# Altium schematic sheet PDM Mk21 - Mini.SchDoc
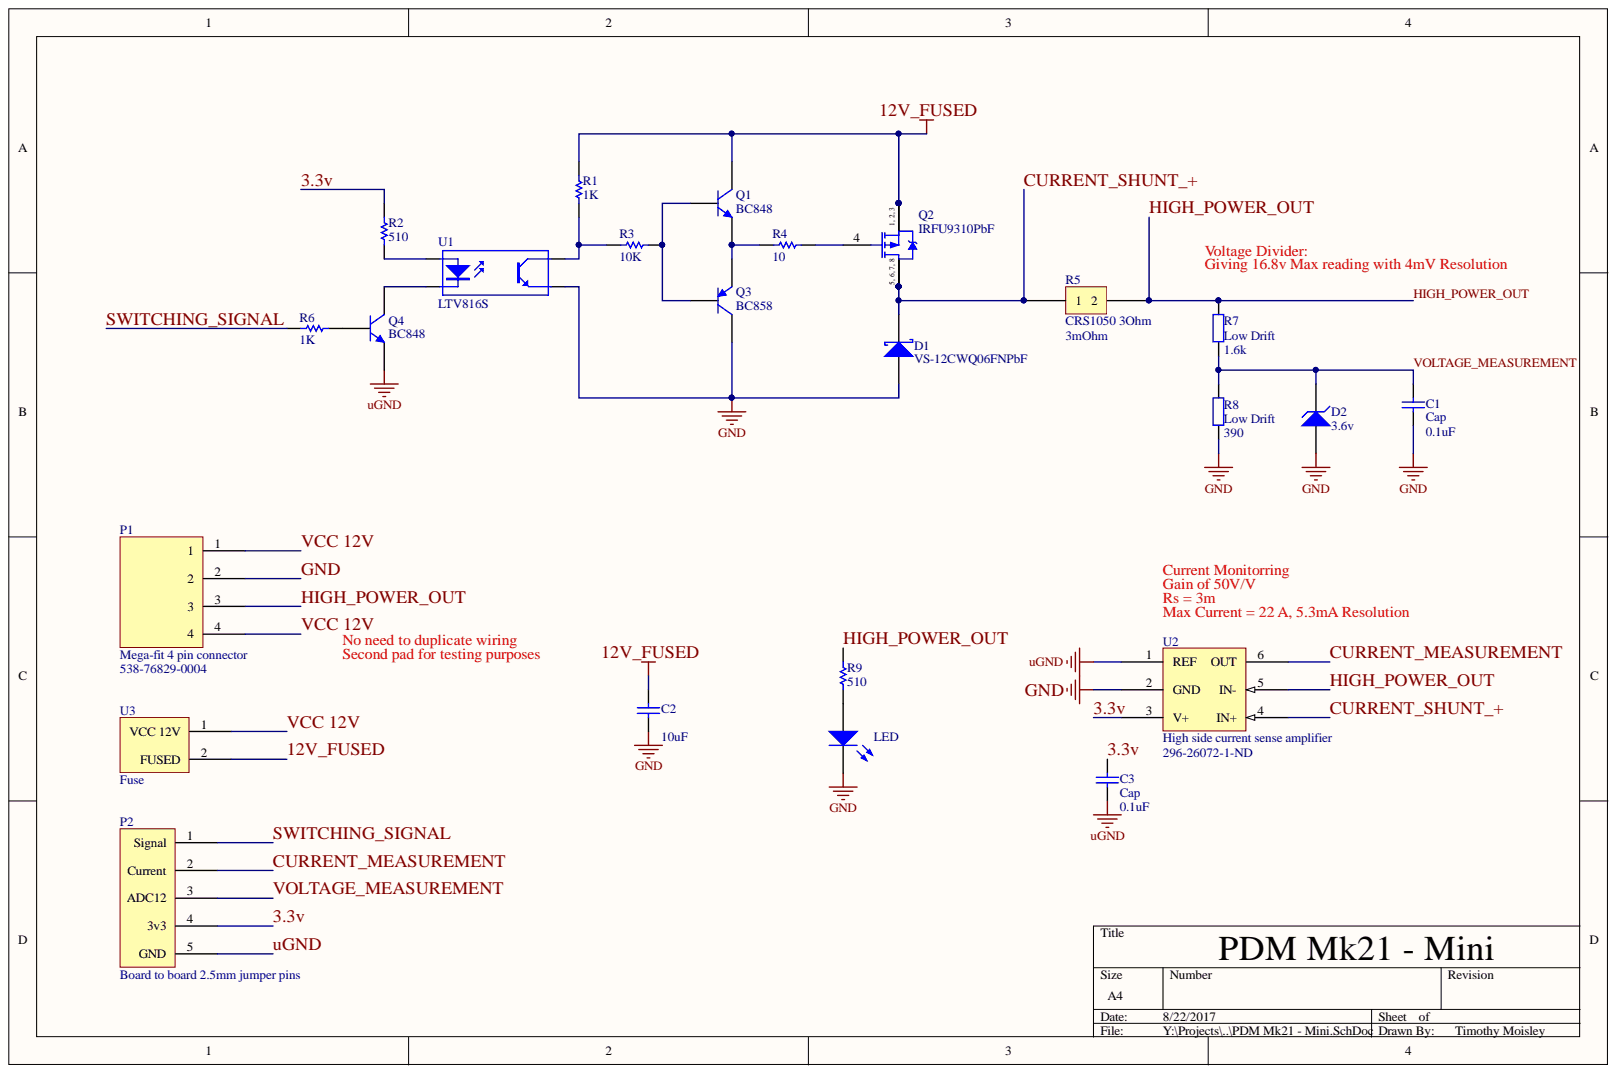
[diagram p0: PDM Mk21 - Mini.SchDoc]

## Parts
C1  Cap  value 0.1uF  [C0805]
C2  value 10uF  [C0805]  (hidden comment: Cap)
C3  Cap  value 0.1uF  [C0805]
D1  VS-12CWQ06FNPbF  [TO-252AA_N]
D2  3.6v  [BZX84CxxxET1G]
LED  (no value)  [LED-0]
P1  Mega-fit 4 pin connector  Supplier Part No.: 538-76829-0004  [Mega-fit 4 pin connector]
P2  Board to board 2.5mm jumper pins  [Board-Board Jumper]
Q1  BC848  [SOT-23_L]
Q2  IRFU9310PbF  [SO8_N]
Q3  BC858  [SOT-23_L]
Q4  BC848  [SOT-23_L]
R1  value 1K  [C0805]  (hidden comment: GND)
R2  value 510  [C0805]  (hidden comment: GND)
R3  value 10K  [C0805]  (hidden comment: GND)
R4  value 10  [C0805]  (hidden comment: Res1)
R5  CRS1050 3Ohm  value 3mOhm  [CRS1050]
R6  value 1K  [C0805]  (hidden comment: IN)
R7  Low Drift  value 1.6k  [R0805]
R8  Low Drift  value 390  [R0805]
R9  value 510  [C0805]  (hidden comment: GND)
U1  LTV816S  [DIP-4]
U2  High side current sense amplifier  Supplier Part Number: 296-26072-1-ND  [INA212]
U3  Fuse  [Fuse]

## Nets
3.3V: C3.2 P2.4(3v3) R2.1 U2.3(V+)
12V_FUSED: C2.2 Q1.3 Q2.1 Q2.2 Q2.3 R1.1 U3.2
CURRENT_MEASUREMENT: P2.2(Current) U2.6(OUT)
CURRENT_SHUNT_+: D1.1 Q2.5 Q2.6 Q2.7 Q2.8 R5.1 U2.4(IN+)
GND: C1.1 C2.1 D1.3 D2.1 LED.2 P1.2 Q3.3 R8.1 U1.3 U2.2(GND)
HIGH_POWER_OUT: P1.3 R5.2 R7.2 R9.1 U2.5(IN-)
SWITCHING_SIGNAL: P2.1(Signal) R6.1
UGND: C3.1 P2.5(GND) Q4.2 U2.1(REF)
VCC 12V: P1.1 P1.4 U3.1
VOLTAGE_MEASUREMENT: C1.2 D2.2 P2.3(ADC12) R7.1 R8.2
NetLED_1: LED.1 R9.2
NetQ1_1: Q1.1 Q3.1 R3.2
NetQ1_2: Q1.2 Q3.2 R4.1
NetQ2_4: Q2.4 R4.2
NetQ4_1: Q4.1 R6.2
NetQ4_3: Q4.3 U1.2
NetR1_2: R1.2 R3.1 U1.4
NetR2_2: R2.2 U1.1

## Library data of the parts
C1 C3 = Cap: Capacitor; Component Kind: Standard; LatestRevisionDate: 17-Jul-2002; LatestRevisionNote: Re-released for DXP Platform.; Library Name: Miscellaneous Devices.IntLib; PackageDescription: Radial Cap, Thru-Hole; 2 Leads; 0.3 in Pin Spacing; PackageReference: RAD-0.3; Published: 8-Jun-2000; Signal Integrity: Cap; Simulation: CAP; Publisher: Altium Limited
C2 = Cap: Capacitor; Manufacturer part no.: 490-5523-1-ND; Component Kind: Standard; Library Name: Miscellaneous Devices.IntLib; Signal Integrity: Cap; Simulation: CAP; Supplier: Digikey; Supplier Part no.: 490-5523-1-ND
D1 = MBR1645: Schottky Rectifier; Note: Terminal 2 is the TAB and is electrically connected to the cathode; Component Kind: Standard; ComponentLink1Description: Manufacturer Link; ComponentLink1URL: http://www.irf.com/; ComponentLink2Description: Datasheet; ComponentLink2URL: http://www.irf.com/product-info/datasheets/data/mbr1635.pdf; DatasheetVersion: Dec-1997; LatestRevisionDate: 17-Jul-2002; LatestRevisionNote: Re-released for DXP Platform.; Library Name: IR Rectifier - Schottky.IntLib; PackageDescription: TO, Thru-Hole, Vertical, Heatsink Mounted; 3 In-Line Leads (1 Absent); Pitch 2.54 mm; PackageReference: TO-220AC; PackageVersion: Feb-1998; Published: 8-Jun-2000; Signal Integrity: MBR1645; Publisher: Altium Limited
D2 = D Zener: Zener Diode; Component Kind: Standard; LatestRevisionDate: 17-Jul-2002; LatestRevisionNote: Re-released for DXP Platform.; Library Name: Miscellaneous Devices.IntLib; PackageDescription: Diode, Thru-Hole; 2 Leads; 0.7 in Pin Spacing; PackageReference: DIODE-0.7; Published: 8-Jun-2000; Signal Integrity: D Zener; Simulation: ZENER; Publisher: Altium Limited
LED = LED0: Typical INFRARED GaAs LED; Component Kind: Standard; LatestRevisionDate: 08-Jul-2005; LatestRevisionNote: Mech Layer 1 removed.; Library Name: Miscellaneous Devices.IntLib; PackageDescription: LED; 2 Leads; PackageReference: LED-0; Published: 8-Jun-2000; Simulation: LED0; Publisher: Altium Limited
P1 = Mega-fit 4 pin connector: MOLEX mega-fit 4 pin connector; Manufacturer Part No.: 76829-0004; Component Kind: Standard; Manufacturer: MOLEX; Supplier: Mouser; Library Name: PDM Mk21 - Mini.SchLib
P2 = Board-Board Jumper: Component Kind: Standard; Description: Board to board 2.5mm jumper pins; Library Name: PDM Mk21 - Mini.SchLib
Q1 Q4 = BC817-25: NPN General Purpose Amplifier; Component Kind: Standard; ComponentLink1Description: Manufacturer Link; ComponentLink1URL: http://www.fairchildsemi.com/; ComponentLink2Description: Discontinuation Notice; ComponentLink2URL: http://www.fairchildsemi.com/obsolete/obs.jsp; DatasheetVersion: 1997; LatestRevisionDate: 20-Nov-2009; LatestRevisionNote: IPC-7351 Footprint Added.; Library Name: FSC Discrete BJT.IntLib; PackageDescription: 3-Pin SOT-23 Package 0.95 mm Pitch, 2.3 mm Lead Span; PackageReference: SOT-23A; PackageVersion: Sep-1998; Published: 8-Jun-2000; Signal Integrity: BC817-25; Simulation: BC817-25; Publisher: Altium Limited
Q2 = IRF7220: HEXFET Power MOSFET - International Rectifier; Code_IPC: SOIC127P600-8; Code_JEDEC: MS-012-AA; Component Kind: Standard; ComponentLink1Description: Manufacturer Link; ComponentLink1URL: http://www.irf.com/; ComponentLink2Description: Datasheet; ComponentLink2URL: http://www.irf.com/product-info/datasheets/data/irf7220.pdf; DatasheetVersion: 16-Jul-1999; LatestRevisionDate: 13-Apr-2006; LatestRevisionNote: IPC-7351 Footprint Added.; Library Name: IR Discrete MOSFET - Power.IntLib; PackageDescription: 8-Pin Plastic Small Outline Integrated Circuit 1.27 mm Pitch; PackageReference: SO-8; PackageVersion: Feb-2001; Published: 17-Sep-2003; Publisher: Altium Limited
Q3 = BC807-16: PNP General Purpose Amplifier; Component Kind: Standard; ComponentLink1Description: Manufacturer Link; ComponentLink1URL: http://www.fairchildsemi.com/; ComponentLink2Description: Datasheet; ComponentLink2URL: http://www.fairchildsemi.com/ds/BC%2FBC807.pdf; DatasheetVersion: 1997; LatestRevisionDate: 20-Nov-2009; LatestRevisionNote: IPC-7351 Footprint Added.; Library Name: FSC Discrete BJT.IntLib; PackageDescription: 3-Pin SOT-23 Package 0.95 mm Pitch, 2.3 mm Lead Span; PackageReference: SOT-23A; PackageVersion: Sep-1998; Published: 8-Jun-2000; Signal Integrity: BC807-16; Simulation: BC807-16; Publisher: Altium Limited
R1-R4 R6 R9 = Res1: Resistor; Component Kind: Standard; LatestRevisionDate: 17-Jul-2002; LatestRevisionNote: Re-released for DXP Platform.; Library Name: Miscellaneous Devices.IntLib; PackageDescription: Axial Device, Thru-Hole; 2 Leads; 0.3 in Pin Spacing; PackageReference: AXIAL-0.3; Published: 8-Jun-2000; Signal Integrity: Res; Simulation: RESISTOR; Publisher: Altium Limited
R5 = CSR1050: Current Shunt Resistor; Manufacturer part no.: CRS1050 R003; Maunfacturer: Arcol; Component Kind: Standard; Supplier: Mouser; Supplier Part no.: 284-CRS1050R003J100T; Library Name: PDM Mk21 - Mini.SchLib
R7 R8 = Res2: Resistor; Component Kind: Standard; LatestRevisionDate: 17-Jul-2002; LatestRevisionNote: Re-released for DXP Platform.; Library Name: Miscellaneous Devices.IntLib; PackageDescription: Axial Device, Thru-Hole; 2 Leads; 0.4 in Pin Spacing; PackageReference: AXIAL-0.4; Published: 8-Jun-2000; Publisher: Altium Limited
U1 = Optoisolator1: 4-Pin Phototransistor Optocoupler; Component Kind: Standard; DatasheetVersion: Nov-2003; LatestRevisionDate: 04-Mar-2005; LatestRevisionNote: Sch pins 3 & 4 Swapped.; Library Name: Miscellaneous Devices.IntLib; PackageReference: DIP-4; Published: 24-Mar-1999; Publisher: Altium Limited
U2 = INA212: Manufacturer: Texas Instruments; Manufacturer part no.: INA212CIDCKR; Component Kind: Standard; Description: High side current sense amplifier; Supplier: Digikey; Library Name: PDM Mk21 - Mini.SchLib
U3 = Fuse: Component Kind: Standard; Library Name: PDM Mk21 - Mini.SchLib
